# Altium schematic sheet I2C_PowerMonitor_INA226.SchDoc
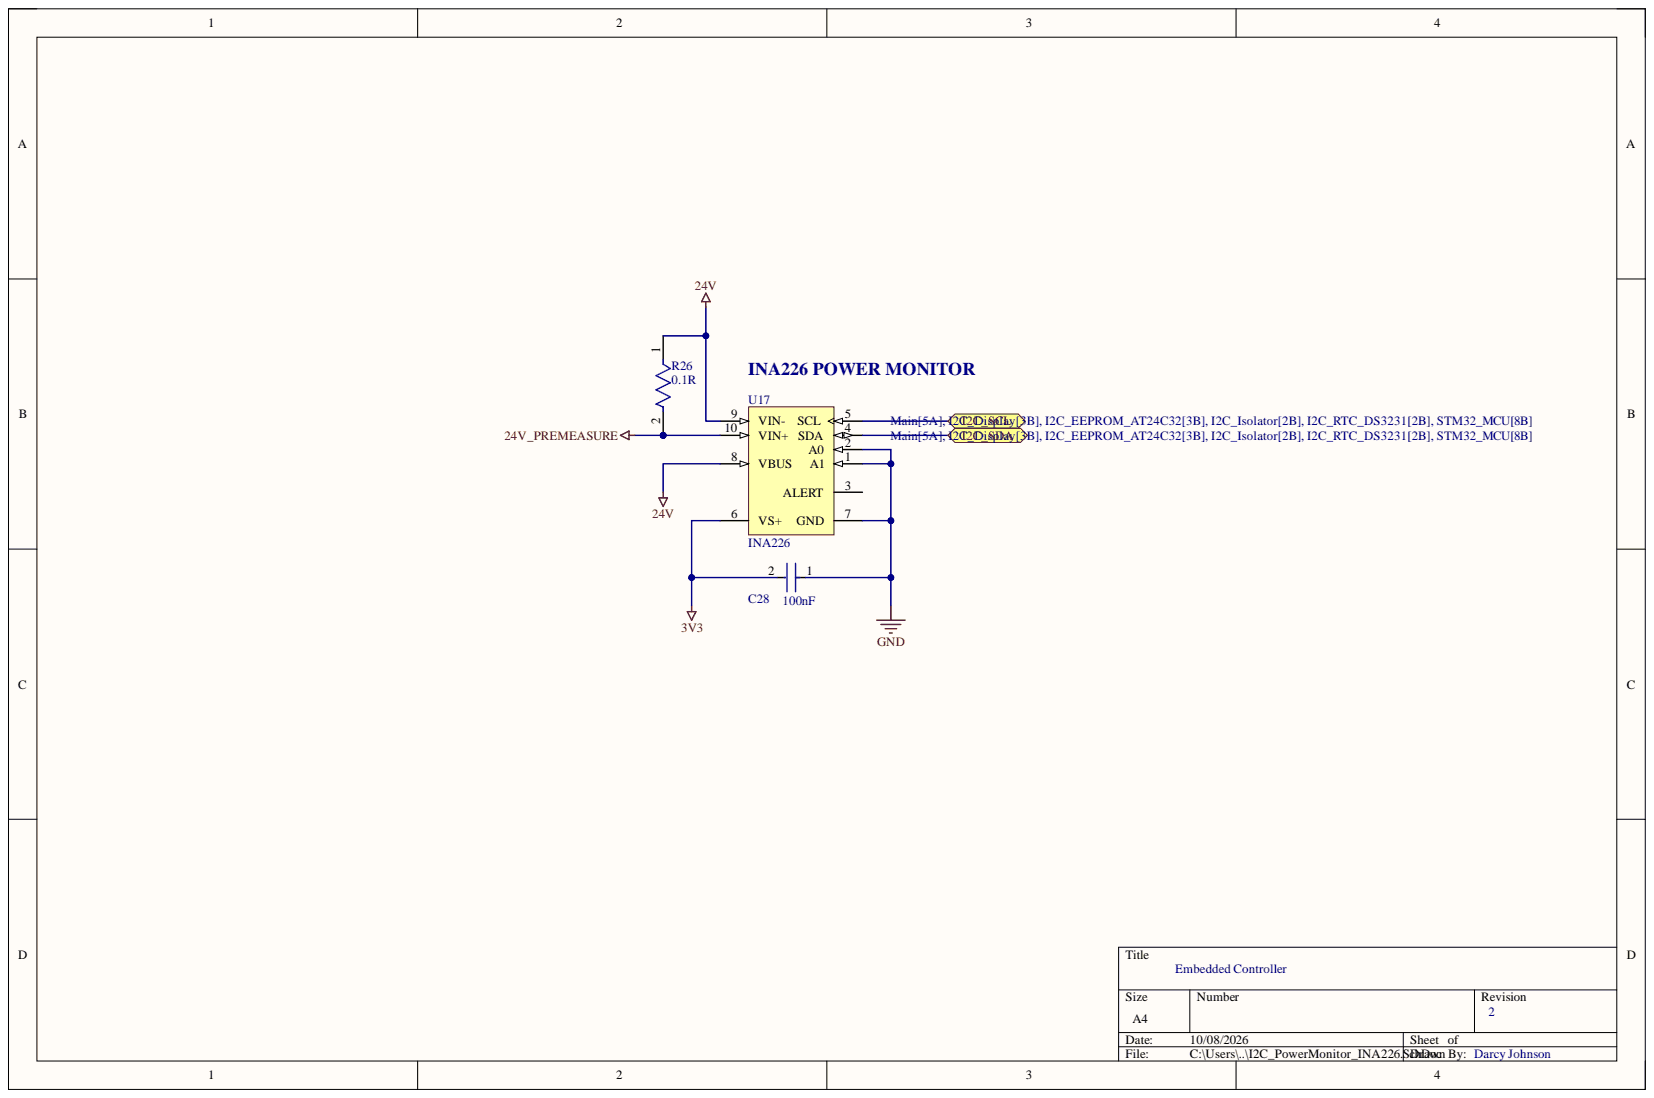
[diagram p0: I2C_PowerMonitor_INA226.SchDoc]

## Parts
C28  100nF  [FP-CGA3E-0_3-0_1-IPC_A]
R26  0.1R  [FP-RMCF2512-IPC_B]
U17  INA226  [DGS0010A_L]

## Ports
I2C_SCL, I2C_SDA

## Nets
3V3: C28.2 U17.6(VS+)
24V: R26.1 U17.8(VBUS) U17.9(VIN-)
24V_PREMEASURE: R26.2 U17.10(VIN+)
GND: C28.1 U17.1(A1) U17.2(A0) U17.7(GND)
I2C_SCL: U17.5(SCL) ; port I2C_SCL
I2C_SDA: U17.4(SDA) ; port I2C_SDA
NetU17_3: U17.3(ALERT)

## Library data of the parts
C28 = CMP-2000-07546-2: Cap Ceramic 100nF 50V X7R 10% Pad SMD 0603 +125°C Automotive T/R; Capacitance: 100nF; Case Code (Imperial): 0603; Case Code (Metric): 1608; Case/Package: 0603; Component Kind: Standard; Composition: Ceramic; Depth: 0.8mm; Dielectric: X7R; Height: 0.8mm; Lead Free: Lead Free; Length: 1.6mm; Library Name: Altium Content Vault; Max Operating Temperature: 125°C; Min Operating Temperature: -55°C; Mount: Surface Mount; Package Quantity: 1; Packaging: Tape and Reel; Radiation Hardening: No; Ratings: AEC-Q200; REACH SVHC: No SVHC; Termination: SMD/SMT; Thickness: 0.035inch; Tolerance: 10%; Voltage: 50V; Voltage Rating: 50V; Voltage Rating (DC): 50V; Weight: 7.1E-05oz; Width: 0.8mm
R26 = CMP-26527-001289-1: 0.1Ω ±5% 1W 2512 Thick Film Chip Resistor AEC-Q200 compliant; Resistance: 100mR; Temperature Coefficient: 200ppm/°C; Case Code (Imperial): 2512; Case Code (Metric): 6432; Case/Package: 2512; Component Kind: Standard; Construction: Rectangular; Contact Plating: Tin; Depth: 3.2mm; Features: Automotive AEC-Q200; Height: 0.028inch; Lead Free: Lead Free; Length: 0.248inch; Library Name: Altium Content Vault; Max Operating Temperature: 155°C; Max Power Dissipation: 1W; Military Standard: Not; Min Operating Temperature: -55°C; Mount: Surface Mount; Number of Terminations: 2; Packaging: Tape and Reel; Power Rating: 1W; Radiation Hardening: No; Resistor Type: Thick Film; RoHS Compliant: Yes; Series: RMCF; Size Code: 2512; Tolerance: 5%; Width: 0.126inch
U17 = CMP-0704-00058-2: High-Side Measurement, Bi-Directional Current / Power Monitor with I2C Interface, 2.7 to 5.5 V, -40 to 125 degC, 10-pin SOP (DGS10), Green (RoHS & no Sb/Br); PartNumber: INA226AIDGSR; CMRR(Min)(dB): 126; Common Mode Range(Max)(V): 36; Common Mode Range(Min)(V): 0; Gain(V/V): 1; Input Offset (+/-)(Max)(uV): 10; Input Offset Drift (+/-)(Max)(uV/Degrees Celsius): 0.1; Quiescent Current (+/-)(Typ)(mA): 0.33; Rating: Catalog; Small-Signal Bandwidth(Typ)(MHz): 0.007; SubFamily: Current Shunt Monitor; Vs(Max)(V): 5.5; Vs(Min)(V): 2.7; Code_JEDEC: MO-153; Component Kind: Standard; ComponentLink1Description: Manufacturer URL; ComponentLink1URL: http://www.ti.com/; ComponentLink2Description: Datasheet; ComponentLink2URL: http://www.ti.com/general/docs/lit/getliterature.tsp?genericPartNumber=INA226&fileType=pdf; ComponentLink3Description: Package Specification; ComponentLink3URL: http://www.ti.com/lit/ml/mpds035b/mpds035b.pdf; DatasheetVersion: SBOS547; Eco-Plan: Green (RoHS & no Sb/Br); Library Name: Altium Content Vault; Mounting Technology: Surface Mount; PackageDescription: 10-Pin Plastic Small Outline, Body 3 x 3 mm, Pitch 0.5 mm; PackageReference: DGS0010A; PackageVersion: 4073272/C, 02/2004
